# Altium schematic sheet IMX7S_CPU_INTERFACES_2.SchDoc
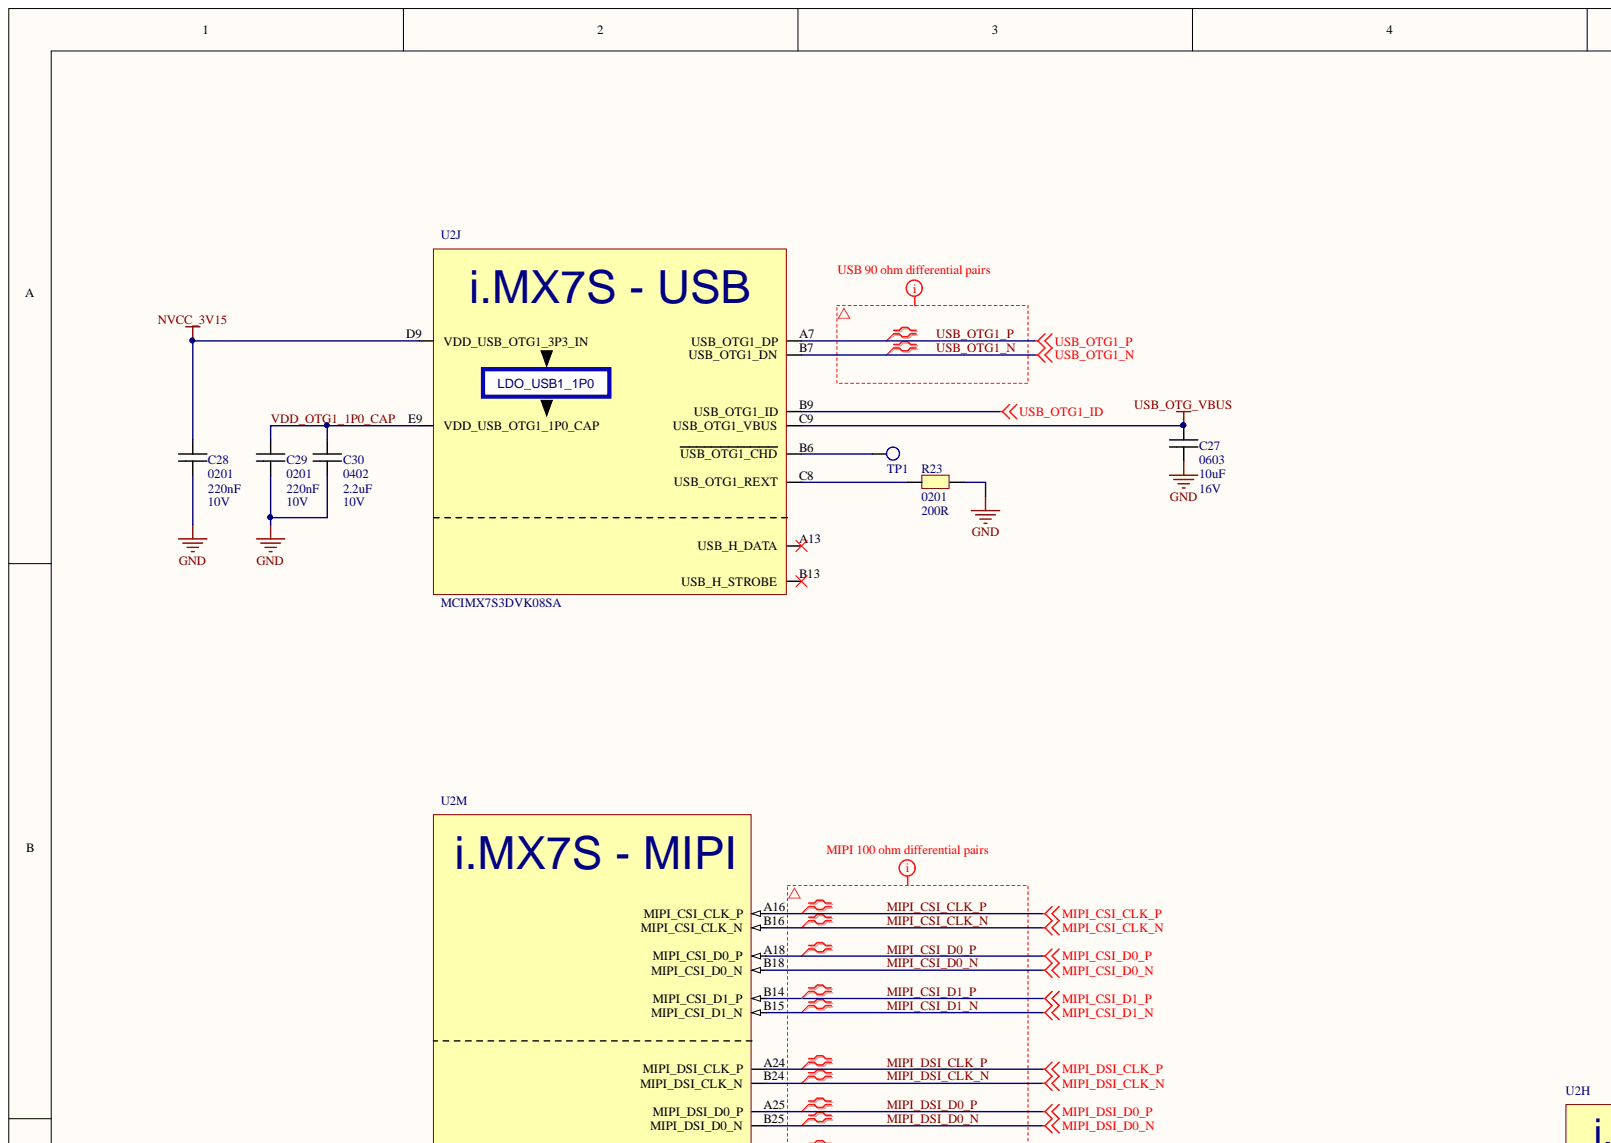
[diagram p0: IMX7S_CPU_INTERFACES_2.SchDoc, top left]
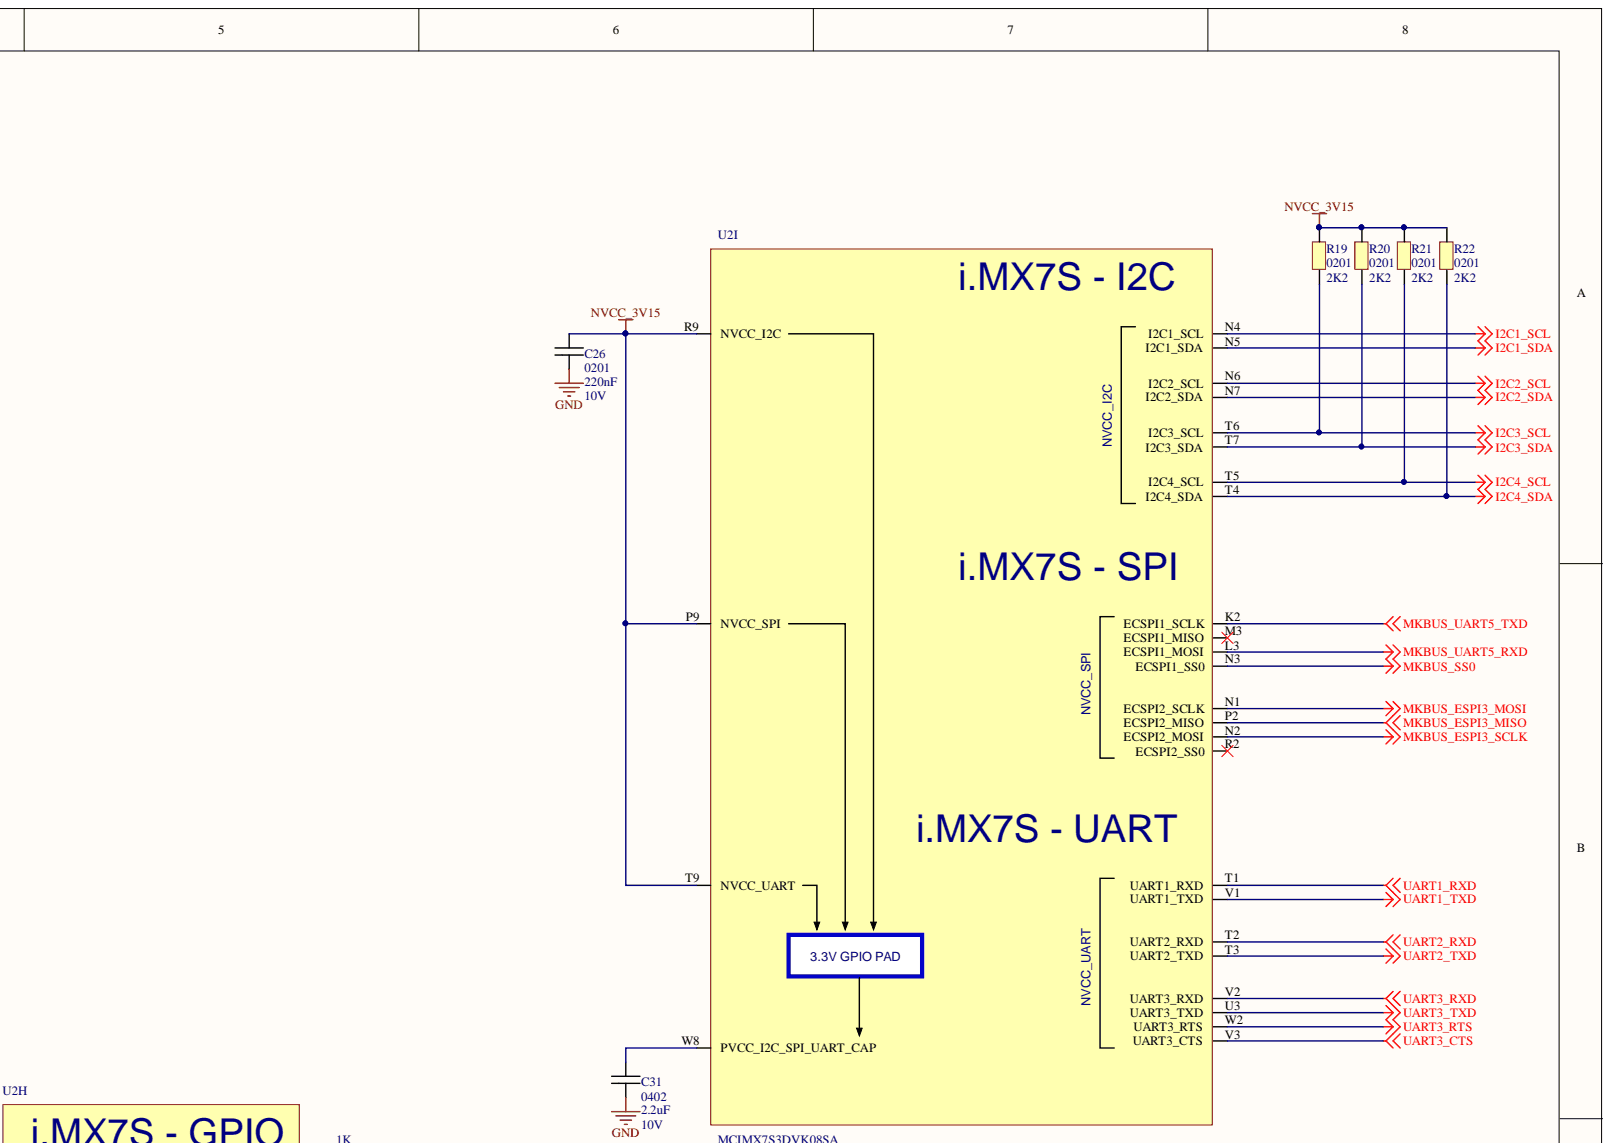
[diagram p1: IMX7S_CPU_INTERFACES_2.SchDoc, top right]
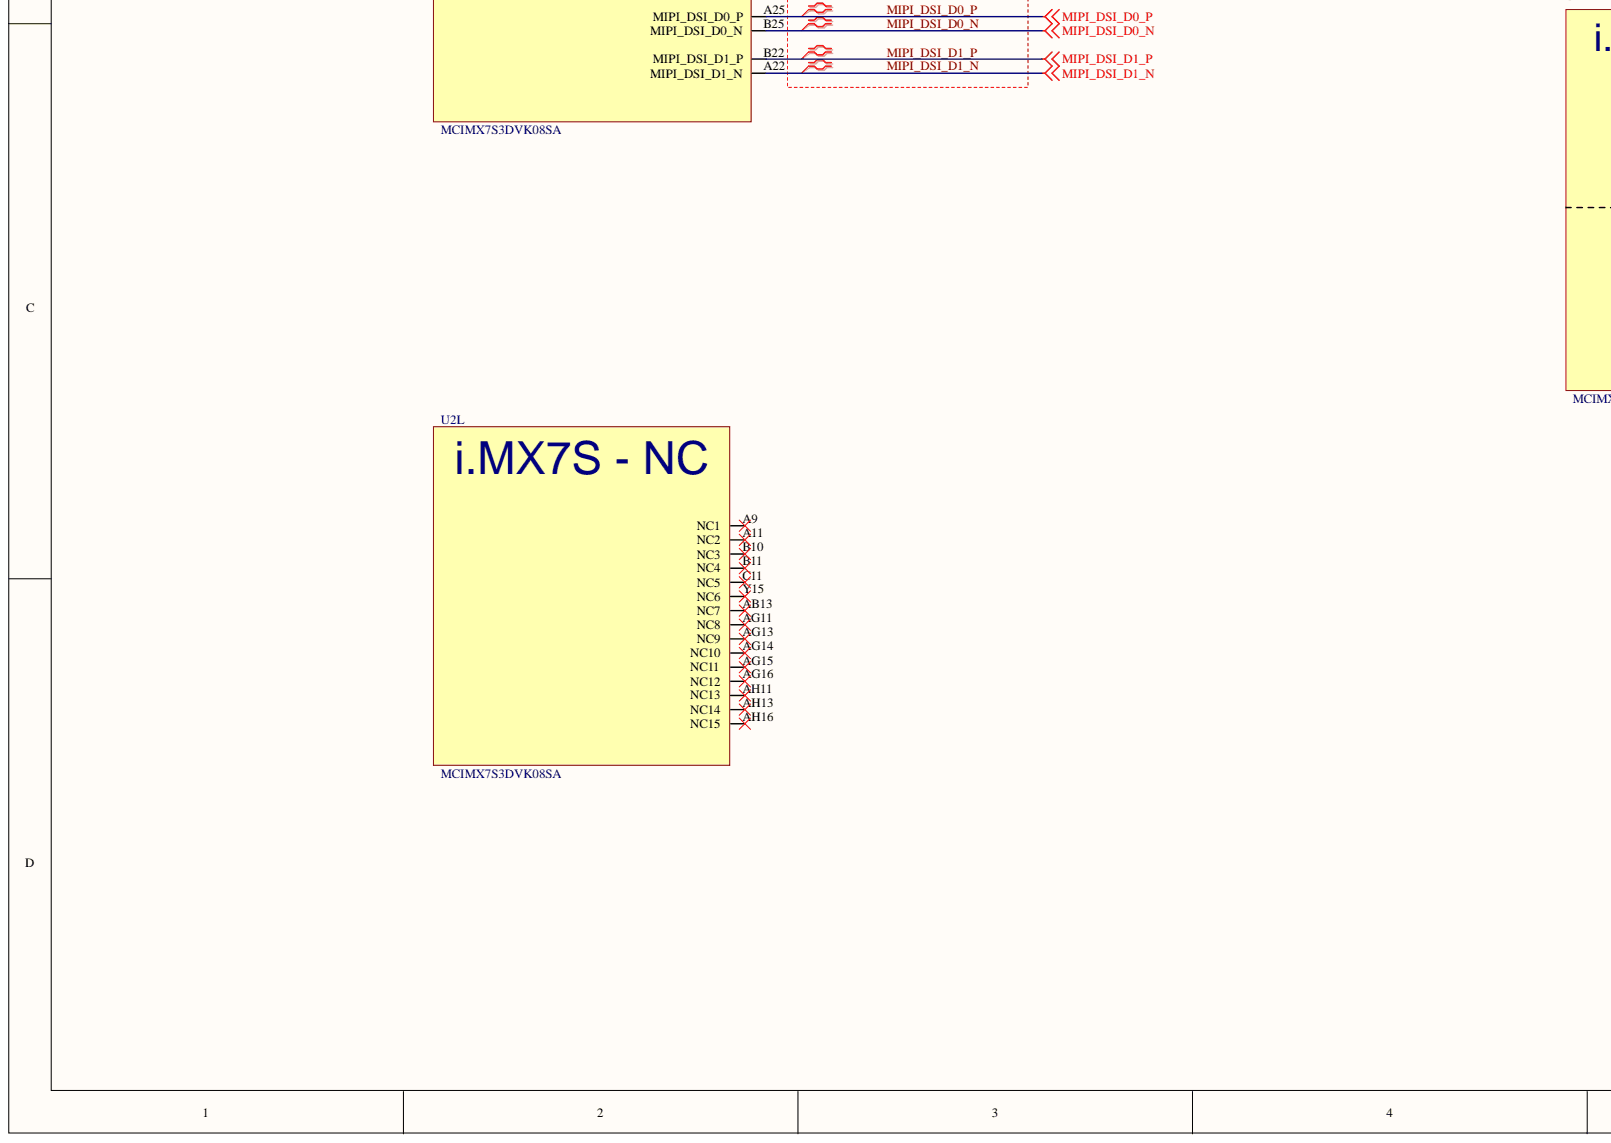
[diagram p2: IMX7S_CPU_INTERFACES_2.SchDoc, bottom left]
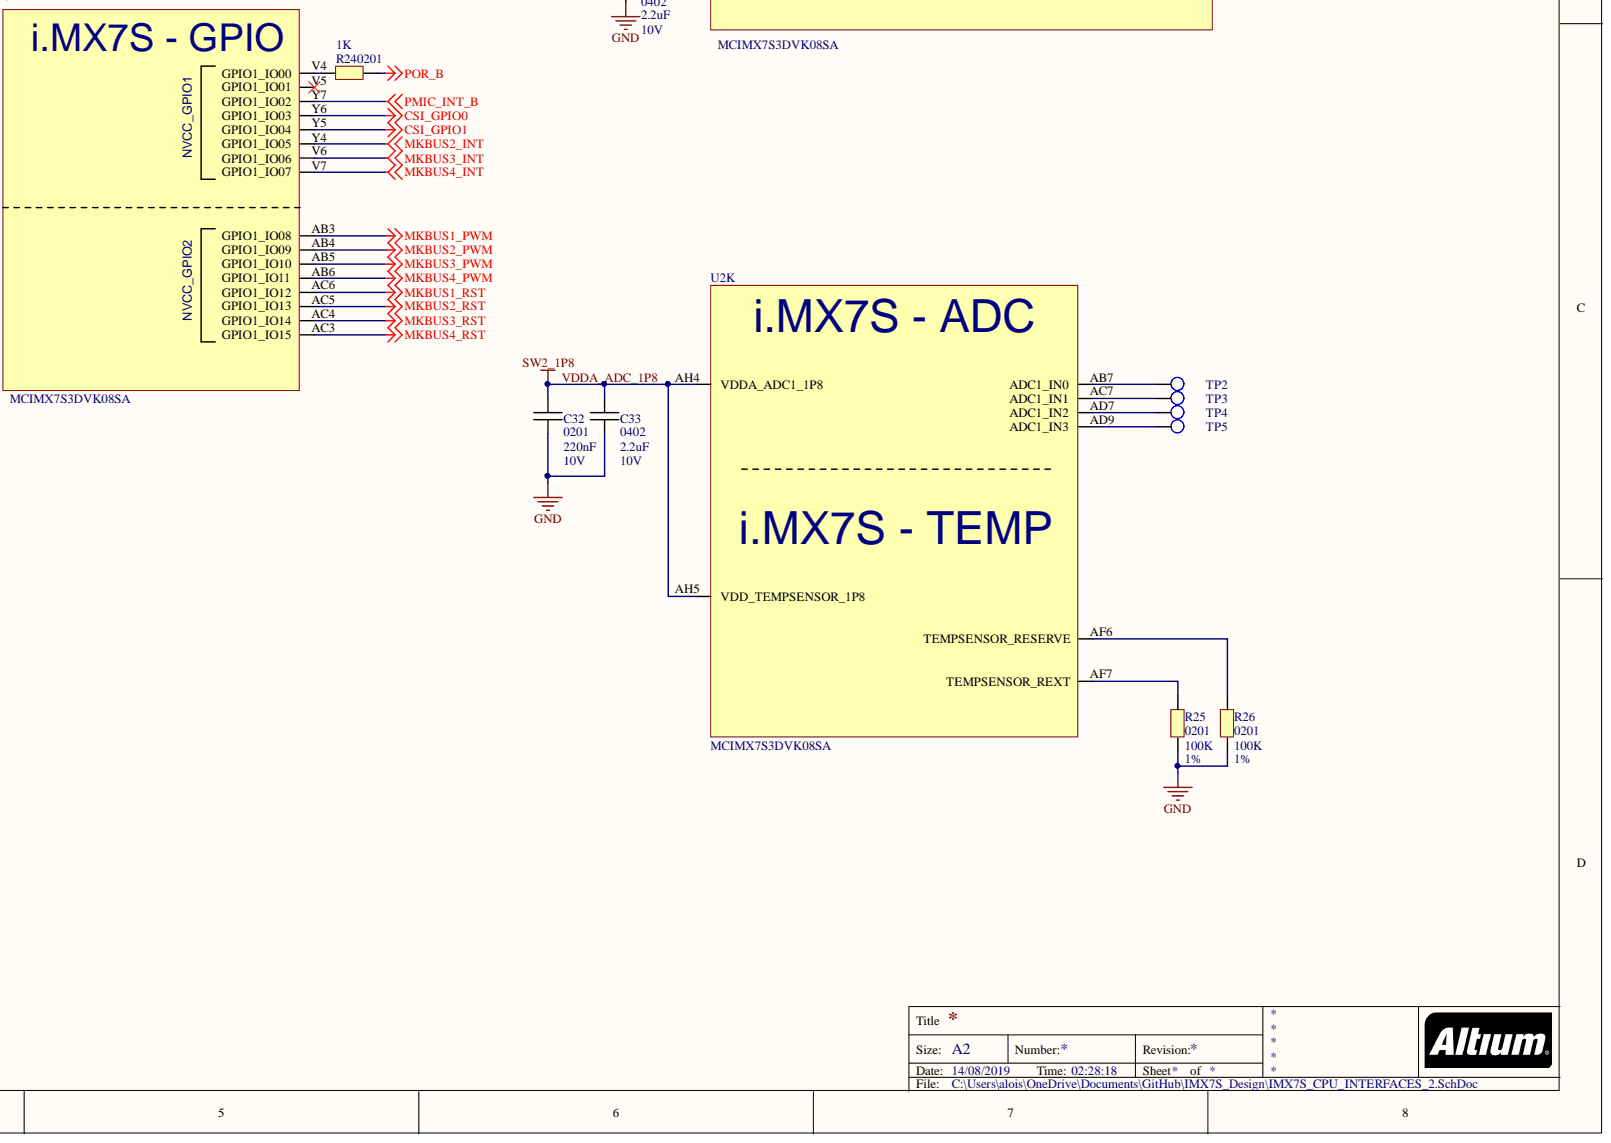
[diagram p3: IMX7S_CPU_INTERFACES_2.SchDoc, bottom right]

## Parts
C26  value 220nF  PACKAGE: 0201  VOLTAGE: 10V  [C0201]  (hidden comment: C0201)
C27  value 10uF  PACKAGE: 0603  VOLTAGE: 16V  [C0603]  (hidden comment: C0603)
C28  value 220nF  PACKAGE: 0201  VOLTAGE: 10V  [C0201]  (hidden comment: C0201)
C29  value 220nF  PACKAGE: 0201  VOLTAGE: 10V  [C0201]  (hidden comment: C0201)
C30  value 2.2uF  PACKAGE: 0402  VOLTAGE: 10V  [C0402]  (hidden comment: C0402)
C31  value 2.2uF  PACKAGE: 0402  VOLTAGE: 10V  [C0402]  (hidden comment: C0402)
C32  value 220nF  PACKAGE: 0201  VOLTAGE: 10V  [C0201]  (hidden comment: C0201)
C33  value 2.2uF  PACKAGE: 0402  VOLTAGE: 10V  [C0402]  (hidden comment: C0402)
R19  value 2K2  PACKAGE: 0201  [R0201]  (hidden comment: R0201)
R20  value 2K2  PACKAGE: 0201  [R0201]  (hidden comment: R0201)
R21  value 2K2  PACKAGE: 0201  [R0201]  (hidden comment: R0201)
R22  value 2K2  PACKAGE: 0201  [R0201]  (hidden comment: R0201)
R23  R0201  value 200R  PACKAGE: 0201  [R0201]
R24  value 1K  PACKAGE: 0201  [R0201]  (hidden comment: R0201)
R25  value 100K  PACKAGE: 0201  VOLTAGE: 1%  [R0201]  (hidden comment: R0201)
R26  value 100K  PACKAGE: 0201  VOLTAGE: 1%  [R0201]  (hidden comment: R0201)
TP1  TestPoint  [TESTPOINT]  (value not drawn)
TP2  TestPoint  [TESTPOINT]  (value not drawn)
TP3  TestPoint  [TESTPOINT]  (value not drawn)
TP4  TestPoint  [TESTPOINT]  (value not drawn)
TP5  TestPoint  [TESTPOINT]  (value not drawn)
U2  MCIMX7S3DVK08SA  [BGA 488 PIN]  (parts H,I,J,K,L,M)

## Nets
CSI_GPIO0: U2.Y6(GPIO1_IO03)
CSI_GPIO1: U2.Y5(GPIO1_IO04)
GND: C26.1 C27.1 C28.1 C29.1 C30.1 C31.1 C32.1 C33.1 R23.2 R25.1 R26.1
I2C1_SCL: U2.N4(I2C1_SCL)
I2C1_SDA: U2.N5(I2C1_SDA)
I2C2_SCL: U2.N6(I2C2_SCL)
I2C2_SDA: U2.N7(I2C2_SDA)
I2C3_SCL: R19.1 U2.T6(I2C3_SCL)
I2C3_SDA: R20.1 U2.T7(I2C3_SDA)
I2C4_SCL: R21.1 U2.T5(I2C4_SCL)
I2C4_SDA: R22.1 U2.T4(I2C4_SDA)
MKBUS1_PWM: U2.AB3(GPIO1_IO08)
MKBUS1_RST: U2.AC6(GPIO1_IO12)
MKBUS2_INT: U2.Y4(GPIO1_IO05)
MKBUS2_PWM: U2.AB4(GPIO1_IO09)
MKBUS2_RST: U2.AC5(GPIO1_IO13)
MKBUS3_INT: U2.V6(GPIO1_IO06)
MKBUS3_PWM: U2.AB5(GPIO1_IO10)
MKBUS3_RST: U2.AC4(GPIO1_IO14)
MKBUS4_INT: U2.V7(GPIO1_IO07)
MKBUS4_PWM: U2.AB6(GPIO1_IO11)
MKBUS4_RST: U2.AC3(GPIO1_IO15)
MKBUS_ESPI3_MISO: U2.P2(ECSPI2_MISO)
MKBUS_ESPI3_MOSI: U2.N1(ECSPI2_SCLK)
MKBUS_ESPI3_SCLK: U2.N2(ECSPI2_MOSI)
MKBUS_SS0: U2.N3(ECSPI1_SS0)
MKBUS_UART5_RXD: U2.L3(ECSPI1_MOSI)
MKBUS_UART5_TXD: U2.K2(ECSPI1_SCLK)
NVCC_3V15: C26.2 C28.2 R19.2 R20.2 R21.2 R22.2 U2.D9(VDD_USB_OTG1_3P3_IN) U2.P9(NVCC_SPI) U2.R9(NVCC_I2C) U2.T9(NVCC_UART)
PMIC_INT_B: U2.Y7(GPIO1_IO02)
POR_B: R24.1
UART1_RXD: U2.T1(UART1_RXD)
UART1_TXD: U2.V1(UART1_TXD)
UART2_RXD: U2.T2(UART2_RXD)
UART2_TXD: U2.T3(UART2_TXD)
UART3_CTS: U2.V3(UART3_CTS)
UART3_RTS: U2.W2(UART3_RTS)
UART3_RXD: U2.V2(UART3_RXD)
UART3_TXD: U2.U3(UART3_TXD)
USB_OTG1_ID: U2.B9(USB_OTG1_ID)
USB_OTG_VBUS: C27.2 U2.C9(USB_OTG1_VBUS)
MIPI_CSI_CLK_N: U2.B16(MIPI_CSI_CLK_N)
MIPI_CSI_CLK_P: U2.A16(MIPI_CSI_CLK_P)
MIPI_CSI_D0_N: U2.B18(MIPI_CSI_D0_N)
MIPI_CSI_D0_P: U2.A18(MIPI_CSI_D0_P)
MIPI_CSI_D1_N: U2.B15(MIPI_CSI_D1_N)
MIPI_CSI_D1_P: U2.B14(MIPI_CSI_D1_P)
MIPI_DSI_CLK_N: U2.B24(MIPI_DSI_CLK_N)
MIPI_DSI_CLK_P: U2.A24(MIPI_DSI_CLK_P)
MIPI_DSI_D0_N: U2.B25(MIPI_DSI_D0_N)
MIPI_DSI_D0_P: U2.A25(MIPI_DSI_D0_P)
MIPI_DSI_D1_N: U2.A22(MIPI_DSI_D1_N)
MIPI_DSI_D1_P: U2.B22(MIPI_DSI_D1_P)
USB_OTG1_N: U2.B7(USB_OTG1_DN)
USB_OTG1_P: U2.A7(USB_OTG1_DP)
VDD_OTG1_1P0_CAP: C29.2 C30.2 U2.E9(VDD_USB_OTG1_1P0_CAP)
VDDA_ADC_1P8: C32.2 C33.2 U2.AH4(VDDA_ADC1_1P8) U2.AH5(VDD_TEMPSENSOR_1P8)
NetC31_2: C31.2 U2.W8(PVCC_I2C_SPI_UART_CAP)
NetR23_1: R23.1 U2.C8(USB_OTG1_REXT)
NetR24_2: R24.2 U2.V4(GPIO1_IO00)
NetR25_2: R25.2 U2.AF7(TEMPSENSOR_REXT)
NetR26_2: R26.2 U2.AF6(TEMPSENSOR_RESERVE)
NetTP1_1: TP1.1 U2.B6(USB_OTG1_CHD)
NetTP2_1: TP2.1 U2.AB7(ADC1_IN0)
NetTP3_1: TP3.1 U2.AC7(ADC1_IN1)
NetTP4_1: TP4.1 U2.AD7(ADC1_IN2)
NetTP5_1: TP5.1 U2.AD9(ADC1_IN3)
N00503:
N00504:

Not connected: U2 A9(NC1) A11(NC2) A13(USB_H_DATA) AB13(NC7) AG11(NC8) AG13(NC9) AG14(NC10) AG15(NC11) AG16(NC12) AH11(NC13) AH13(NC14) AH16(NC15) B10(NC3) B11(NC4) B13(USB_H_STROBE) C11(NC5) M3(ECSPI1_MISO) R2(ECSPI2_SS0) V5(GPIO1_IO01) Y15(NC6)

## Library data of the parts
R19-R23 = R0201: VOLTAGE: 0V; Component Kind: Standard; Library Name: Schlib_IMX7.SchLib
R24 = R0201: VOLTAGE: 1%; Component Kind: Standard; Library Name: Schlib_IMX7.SchLib
U2 = MCIMX7S3DVK08SD: Component Kind: Standard; Library Name: Schlib_IMX7.SchLib; Supplier 1: Mouser; Supplier Part Number 1: 771-MCIMX7S3DVK08SD
C26 C28 C29 C32 = C0201: Component Kind: Standard; Library Name: Schlib_IMX7.SchLib
C27 = C0603: Component Kind: Standard; Library Name: Schlib_IMX7.SchLib
C30 C31 C33 = C0402: Component Kind: Standard; Library Name: Schlib_IMX7.SchLib
R25 R26 = R0201: Component Kind: Standard; Library Name: Schlib_IMX7.SchLib
TP1-TP5 = TestPoint: Component Kind: Standard; Library Name: Schlib_IMX7.SchLib
